# Altium schematic sheet Connectors.SchDoc
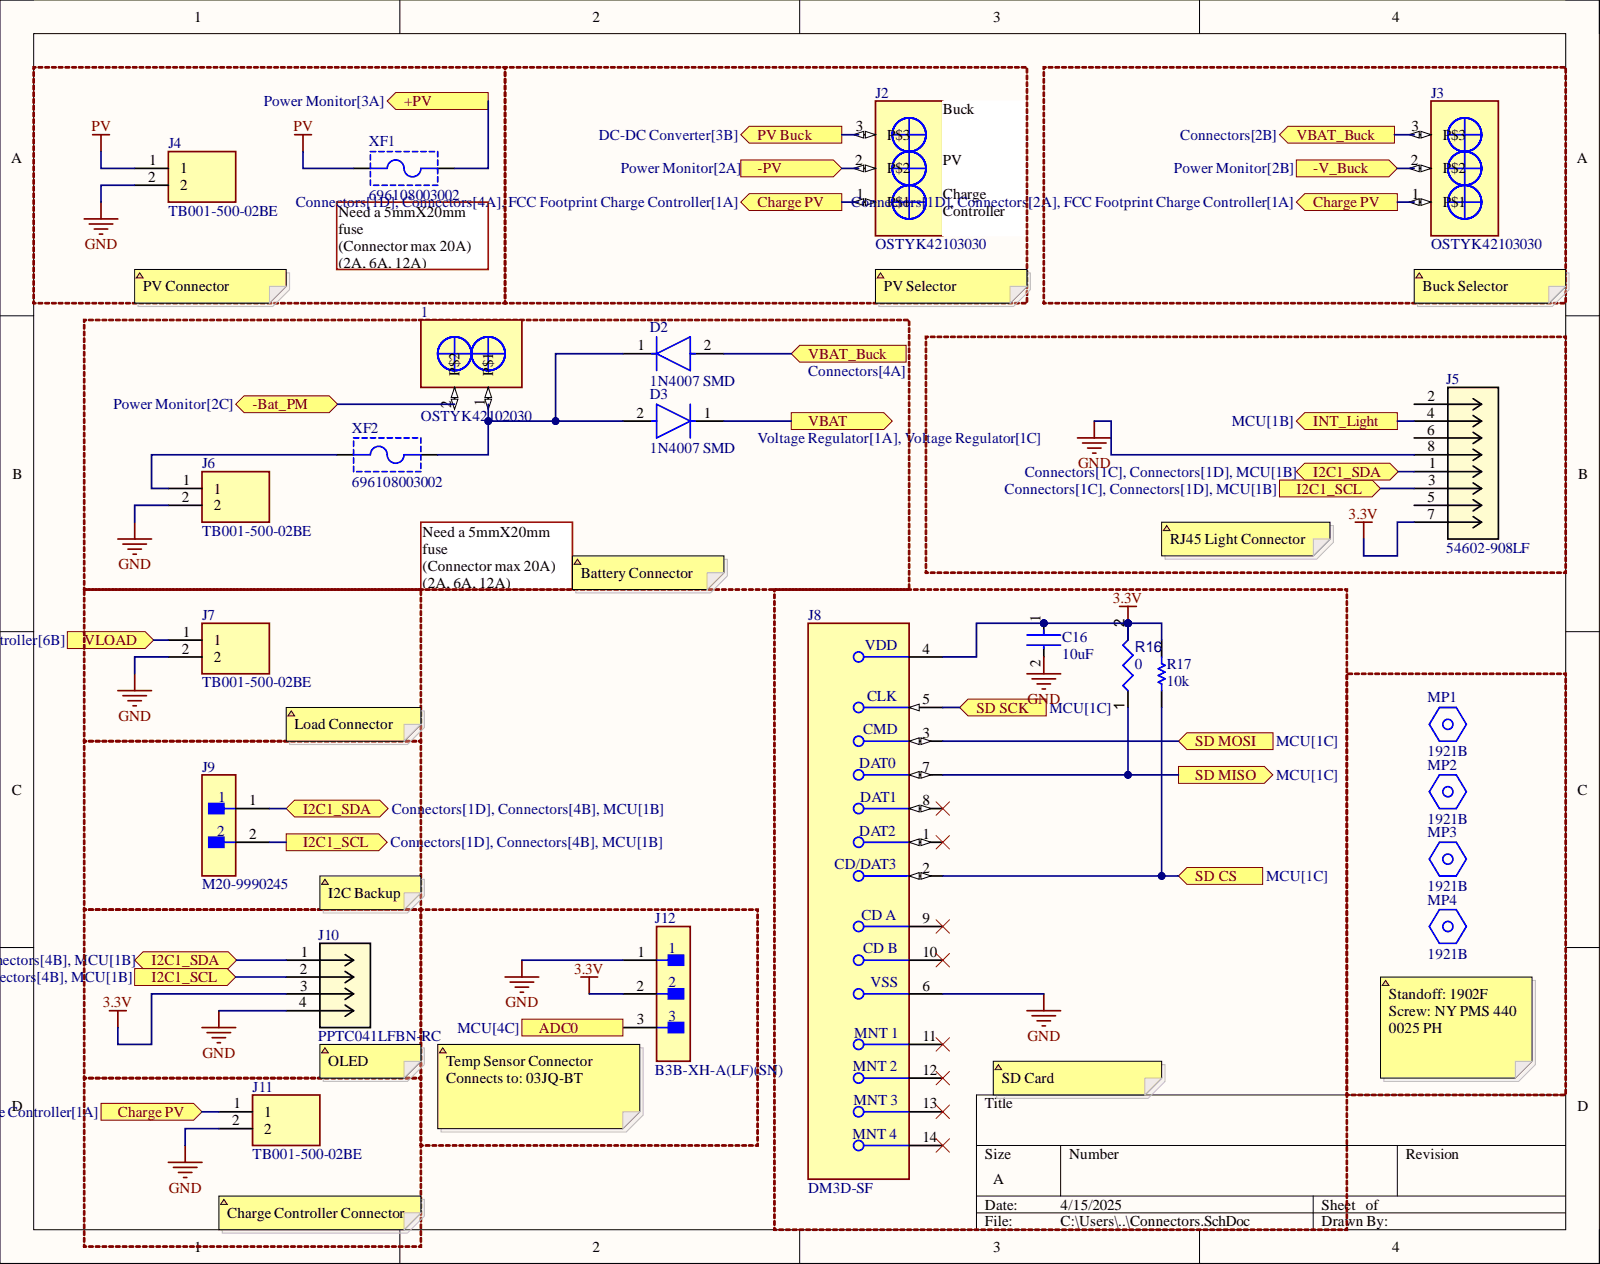
[diagram p0: Connectors.SchDoc]

## Parts
1  OSTYK42102030  [OSTYK42102030]
C16  10uF  [FP-GRM188-0_1-e0_2_0_55-IPC_A]
D2  1N4007 SMD  [FP-1N4007_SMD-IPC_C]
D3  1N4007 SMD  [FP-1N4007_SMD-IPC_C]
J2  OSTYK42103030  [OSTYK42103030]
J3  OSTYK42103030  [OSTYK42103030]
J4  TB001-500-02BE  [CUI_TB001-500-02BE]
J5  54602-908LF  [CONN8_2-908LF_AMP]
J6  TB001-500-02BE  [CUI_TB001-500-02BE]
J7  TB001-500-02BE  [CUI_TB001-500-02BE]
J8  DM3D-SF  [FP-DM3D-SF-MFG]
J9  M20-9990245  [FP-M20-9990245-MFG]
J10  PPTC041LFBN-RC  [CONN_PPTC041LFBN-RC_SUL]
J11  TB001-500-02BE  [CUI_TB001-500-02BE]
J12  B3B-XH-A(LF)(SN)  [FP-B3B-XH-A_LF_SN-MFG]
MP1  1921B  [FP-1921B-MFG]
MP2  1921B  [FP-1921B-MFG]
MP3  1921B  [FP-1921B-MFG]
MP4  1921B  [FP-1921B-MFG]
R16  value 0  [RESC0603(1608)_L]  (hidden comment: 0R3J)
R17  10k  [RESC1609X50X30NL8T20]
XF1  696108003002  [696108003002]
XF2  696108003002  [696108003002]

## Ports
+PV, -Bat_PM, -PV, -V_Buck, ADC0, Charge PV, I2C1_SCL, I2C1_SDA, INT_Light, PV Buck, SD CS, SD MISO, SD MOSI, SD SCK, VBAT, VBAT_Buck, VLOAD

## Nets
3.3V: C16.1 J5.7 J8.4 J10.3 J12.2 R16.2 R17.2
GND: C16.2 J4.2 J5.8 J6.2 J7.2 J8.6 J10.4 J11.2 J12.1
PV: J4.1 XF1.1
-Bat_PM: 1.2 ; port -Bat_PM
-V_Buck: J3.2 ; port -V_Buck
ADC0: J12.3 ; port ADC0
Charge PV: J2.1 J3.1 J11.1 ; port Charge PV
I2C1_SCL: J5.3 J9.2 J10.2 ; port I2C1_SCL
I2C1_SDA: J5.1 J9.1 J10.1 ; port I2C1_SDA
INT_Light: J5.4 ; port INT_Light
PV Buck: J2.3 ; port PV Buck
SD CS: J8.2 R17.1 ; port SD CS
SD MISO: J8.7 R16.1 ; port SD MISO
SD MOSI: J8.3 ; port SD MOSI
SD SCK: J8.5 ; port SD SCK
VBAT: D3.1 ; port VBAT
VBAT_Buck: D2.2 J3.3 ; port VBAT_Buck
VLOAD: J7.1 ; port VLOAD
Net1_1: 1.1 D2.1 D3.2 XF2.2
NetJ2_2: J2.2
NetJ6_1: J6.1 XF2.1
NetXF1_2: XF1.2

Not connected: J5 2 5 6; J8 1 8 9 10 11 12 13 14

## Library data of the parts
1 = OSTYK42102030: 2 Circuit 0.325 _8.26mm_ Barrier Block Connector, Screws with Captive Plate; MF: On Shore; Availability: Unavailable; Component Kind: Standard; Library Name: OSTYK42102030.IntLib; MP: OSTYK42102030; Package: None; Price: None; SnapEDA_Link: https://www.snapeda.com/parts/OSTYK42102030/On%20Shore%20Technology%20Inc./view-part/3076180/?ref=snap
C16 = CMP-2006-02792-2: Chip Multilayer Ceramic Capacitors for General Purpose, 0603, 10uF, X5R, 15%, 20%, 6.3V  GRM188R60J106ME47D; Capacitance: 10uF; Case Code (Imperial): 0603; Case Code (Metric): 1608; Case/Package: 0603; Component Kind: Standard; Depth: 0.8mm; Dielectric: X5R; Height: 0.8mm; Lead Free: Lead Free; Length: 1.6mm; Library Name: Altium Content Vault; Material: Ceramic; Max Operating Temperature: 85°C; Min Operating Temperature: -55°C; Mount: Surface Mount; Package Quantity: 4000; Packaging: Tape and Reel; Radiation Hardening: No; REACH SVHC: No SVHC; Termination: SMD/SMT; Thickness: 0.035inch; Tolerance: 20%; Voltage: 6.3V; Voltage Rating: 6.3V; Voltage Rating (DC): 6.3V; Width: 0.031inch
D2 D3 = CMP-12389-000001-1: Rectifier, 1Kv, 1A, Do-214Ac Rohs Compliant: Yes; Component Kind: Standard; Library Name: Altium Content Vault
J2 J3 = CMP-002-00251-1: 3 Circuit 0.325 (8.26mm) Barrier Block Connector, Screws with Captive Plate; MF: On Shore; Availability: In Stock; Case/Package: None; Check_prices: https://www.snapeda.com/parts/OSTYK42103030/On+Shore+Technology+Inc./view-part/?ref=eda; Component Kind: Standard; Component Type: Standard; Design Item ID: OSTYK42103030; MP: OSTYK42103030; Pins: 3; Price: None; Purchase-URL: https://pricing.snapeda.com/search/part/OSTYK42103030/?ref=eda; SnapEDA_Link: https://www.snapeda.com/parts/OSTYK42103030/On+Shore+Technology+Inc./view-part/?ref=snap; Source: OSTYK42103030.SchLib; Library Name: WPI High Power Rocketry Club
J4 J6 J7 J11 = TB001-500-02BE: 2~24 Poles, Screw Type, Horizontal, 5.0 Pitch, 22~12 (AWG), Terminal Block Connector; MF: CUI Devices; Check_prices: https://www.snapeda.com/parts/TB001-500-02BE/CUI+Devices/view-part/?ref=eda; Component Kind: Standard; CUI_purchase_URL: https://www.cuidevices.com/product/interconnect/connectors/terminal-blocks/tb001-500-series?utm_source=snapeda.com&utm_medium=referral&utm_campaign=snapedaBOM; Digi-Key_Part_Number: 102-6134-ND; Library Name: TB001-500-02BE.IntLib; MP: TB001-500-02BE; Package: None; Purchase-URL: https://pricing.snapeda.com/search/part/TB001-500-02BE/?ref=eda; SnapEDA_Link: https://www.snapeda.com/parts/TB001-500-02BE/CUI+Devices/view-part/?ref=snap
J5 = 54602-908LF: No Description Available; Mfr_Name: Amphenol ICC; Component Kind: Standard; Library Name: 54602-908LF.IntLib; RefDes: RefDes; Type: Type
J8 = CMP-2000-06166-2: CONN MICRO SD CARD PUSH-PULL; Connector Type: Connector; Contact Resistance: 100mR; Current Rating: 500mA; Gender: Female; Insulation Resistance: 1GR; Max Voltage Rating (AC): 125V; Number of Contacts: 8; Pitch: 1.1mm; Component Kind: Standard; Contact Material: Copper Alloy; Contact Plating: Gold; Depth: 11.45mm; Flammability Rating: UL94 V-0; Housing Material: Polymer; Lead Free: Lead Free; Length: 11.95mm; Library Name: Altium Content Vault; Max Current Rating: 500mA; Max Operating Temperature: 85°C; Min Operating Temperature: -25°C; Mount: Surface Mount; Number of Rows: 1; Orientation: Right Angle; Package Quantity: 1; Packaging: Tape and Reel; Radiation Hardening: No; REACH SVHC: No SVHC; Termination: Solder; Voltage Rating (AC): 125V; Width: 11.95mm
J9 = CMP-15831-000105-1: CONN HEADER VERT 2POS 2.54MM; Connector Type: Male Pin; Contact Resistance: 25mR; Current: 3A; Gender: Male; Insulation Resistance: 10GR; Mated Stacking Heights: 16.54mm; Mating Cycles: 300; Number of Contacts: 2; Voltage: 50V; Voltage Rating (AC): 750V; Case/Package: SIL; Color: Black; Component Kind: Standard; Contact Finish - Mating: GOLD; Contact Material: Brass; Contact Mating Length: 0.24inch; Contact Plating: Gold; Contact Shape: Square; Contact Type: Male Pin; Current Rating: 3A; Depth: 2.54mm; Fastening Type: Pull; Flammability Rating: UL94 V-0; Height: 0.12inch; Housing Color: Black; Housing Material: Nylon; Insulation Color: Black; Insulation Material: Nylon; Lead Pitch: 2.54mm; Length: 5.08mm; Library Name: Altium Content Vault; Max Current Rating: 3A; Max Operating Temperature: 105°C; Min Operating Temperature: -40°C; Mount: Through Hole; Number of Poles: 2; Number of Positions: 2; Number of Rows: 1; Orientation: Straight; Package Quantity: 1000; Packaging: Bulk; Pitch: 2.54mm; Radiation Hardening: No; Reach SVHC Compliant: Yes; Series: M20; Termination: Solder; Weight: 0.25lb; Width: 0.25inch; Working Voltage: 750V
J10 = PPTC041LFBN-RC: No Description Available; Mfr_Name: Sullins; Component Kind: Standard; Library Name: PPTC041LFBN-RC.IntLib; RefDes: RefDes; Type: Type
J12 = CMP-17439-000014-3: CONN HEADER VERT 3POS 2.5MM; Contact Resistance: 20mR; Current Rating: 3A; Gender: Male; Insulation Resistance: 1GR; Max Voltage Rating (AC): 250V; Number of Contacts: 3; Wire/Cable Gauge: 22AWG; Color: Natural; Component Kind: Standard; Connector Type: Header; Contact Finish - Mating: TIN; Contact Material: Brass; Contact Plating: Tin; Contact Shape: Square; Contact Type: Male Pin; Depth: 5.75mm; Fastening Type: Detent; Flammability Rating: UL94 V-0; Housing Color: White; Housing Material: Polyamide; Insulation Color: Natural; Insulation Material: Nylon; Lead Free: Lead Free; Lead Pitch: 2.5mm; Length: 9.9mm; Library Name: Altium Content Vault; Max Current Rating: 3A; Max Operating Temperature: 85°C; Max Voltage Rating (DC): 250V; Min Operating Temperature: -25°C; Mount: Through Hole; Number of Positions: 3; Number of Rows: 1; Orientation: Straight; Packaging: Bulk; Pitch: 2.5mm; REACH SVHC: No SVHC; Series: XH; Termination: Solder; Voltage Rating: 250V; Voltage Rating (AC): 250V
MP1-MP4 = CMP-00214-00374361-1: 1921B Standoff, 1/4 Hex, Female, Threaded 4-40, Length .500, Stainless Steel; NexarPartId: 374361; Gender: Female, Female; Outside Diameter: 6.35mm; Color: Natural; Component Kind: Standard; Diameter: 6.35mm; Height: 12.827mm; Lead Free: Lead Free; Length: 12.7mm; Library Name: Altium Content Vault; Material: Stainless Steel; RoHS: Compliant; Shape: Hex; Threaded/Unthreaded: Threaded; Width: 6.35mm
R16 = CMP-009-00063-2: Jumper 0603 (1608 Metric); Case/Package: 0603; Component Kind: Standard; Mounting Technology: SMT; Pins: 2; Library Name: WPI High Power Rocketry Club
R17 = CMP-1666-00005-4: Chip Resistor, 10 KOhm, +/- 1%, 100 mW, -55 to 155 degC, 0603 (1608 Metric), RoHS, Tape and Reel; Manufacturer: Vishay Semiconductor; Voltage Rating: 75 V; Component Kind: Standard; ComponentLink1Description: Manufacturer URL; ComponentLink1URL: http://www.vishay.com/; ComponentLink2Description: Datasheet; ComponentLink2URL: https://datasheet.ciiva.com/11809/0900766b80d98afc-11809037.pdf; DatasheetVersion: 06/2012; Height: 0.45 mm; Length: 1.55 mm; Library Name: Altium Content Vault; Max Operating Temperature: 155 degC; Min Operating Temperature: -55 degC; Mounting Technology: Surface Mount; Package Quantity: 5000; PackageDescription: 2-Pin Surface Mount Device, Body 1.575 x 0.85 mm; PackageReference: 0603; Packaging: Tape and Reel; REACH SVHC: Unknown; RoHSCompliant: true
XF1 XF2 = CMP-1502-00237-2: NexarPartId: 56808807; Fuse Current: 10A; Voltage Rating (AC): 250V; Component Kind: Standard; Library Name: Altium Content Vault; Mount: Through Hole; Packaging: Box; REACH SVHC: Unknown; RoHS: Non-Compliant
